# Altium schematic sheet Top sheet.SchDoc("Top sheet")
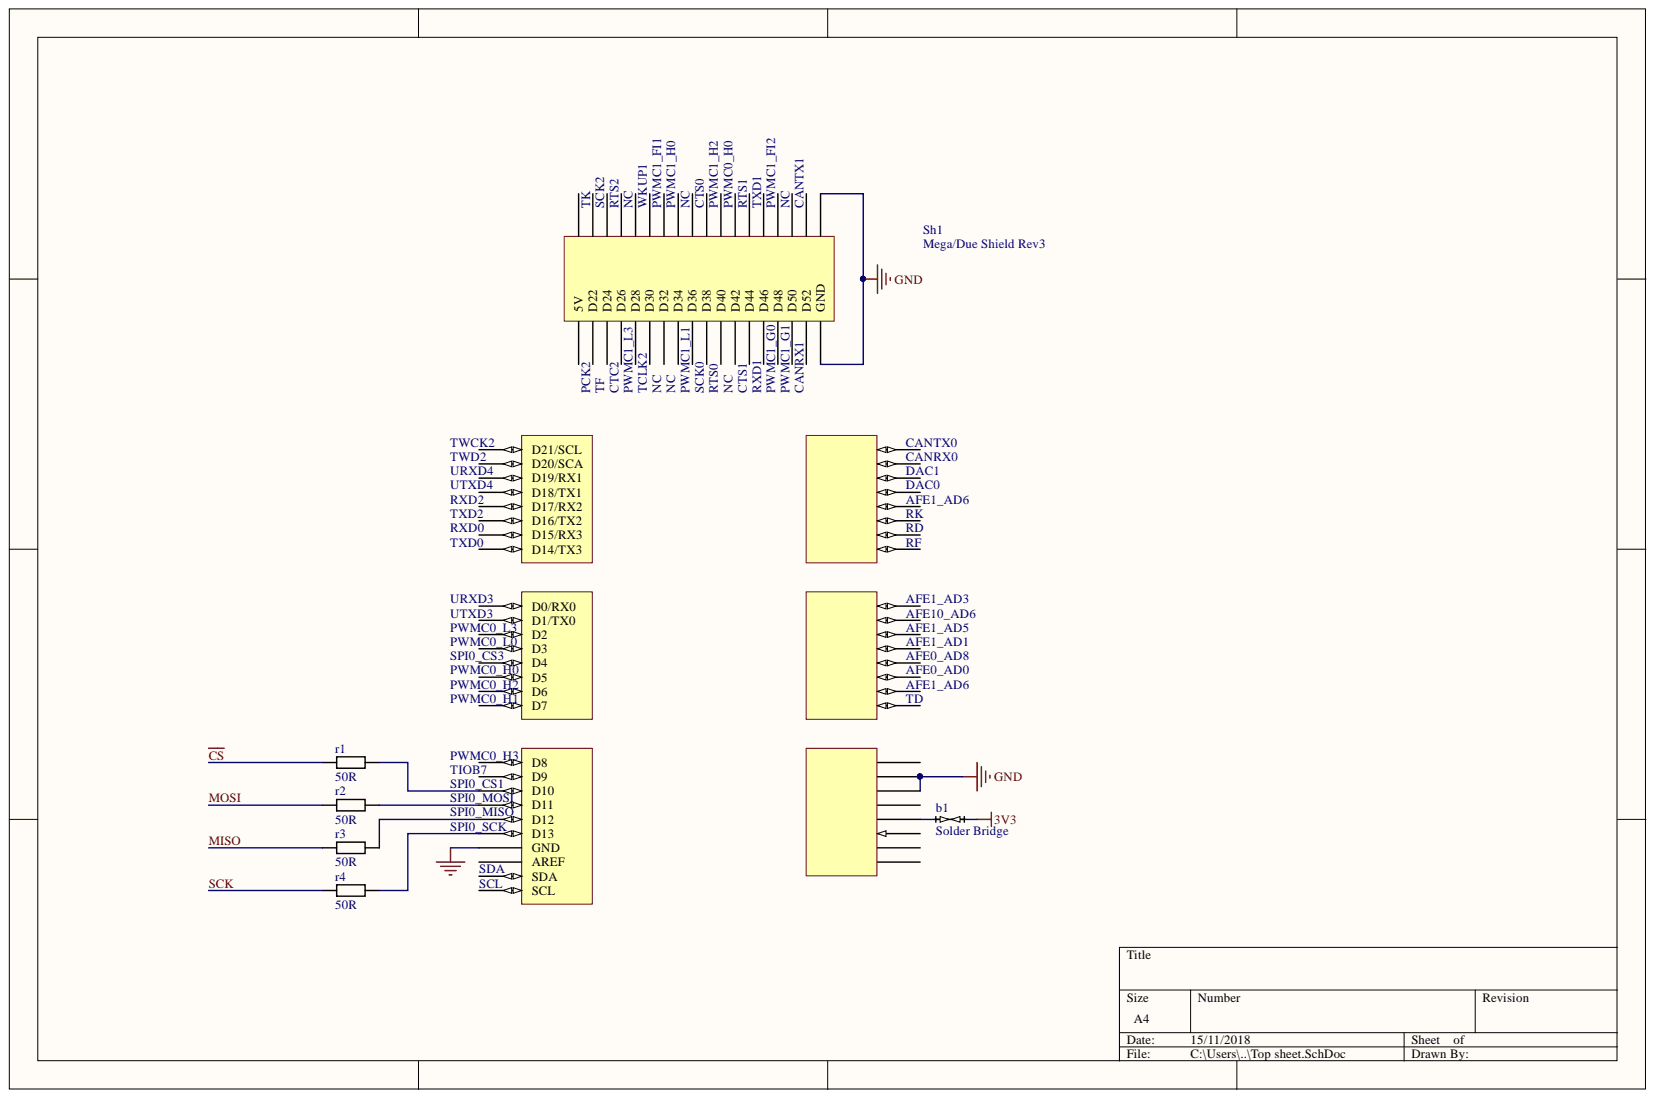
[diagram p0: Top sheet.SchDoc]

## Parts
b1  Solder Bridge  [Solder Bridge]
r1  50R  [0805]
r2  50R  [0805]
r3  50R  [0805]
r4  50R  [0805]
Sh1  Mega/Due Shield Rev3  [Mega2560_R3 Shield]

## Nets
3V3: b1.2
GND: Sh1.6 Sh1.7 Sh1.47(GND) Sh1.83 Sh1.84
~{CS}: r1.1
MISO: r3.1
MOSI: r2.1
SCK: r4.1
Netb1_1: b1.1 Sh1.4
Netr1_2: r1.2 Sh1.43(D10)
Netr2_2: r2.2 Sh1.44(D11)
Netr3_2: r3.2 Sh1.45(D12)
Netr4_2: r4.2 Sh1.46(D13)

Not connected: Sh1 1 2 3 5 8 9 10 11 12 13 14 15 16 17 18 19 20 21 22 23 24 25(D21/SCL) 26(D20/SCA) 27(D19/RX1) 28(D18/TX1) 29(D17/RX2) 30(D16/TX2) 31(D15/RX3) 32(D14/TX3) 33(D0/RX0) 34(D1/TX0) 35(D2) 36(D3) 37(D4) 38(D5) 39(D6) 40(D7) 41(D8) 42(D9) 48(AREF) 49 50 51 52 53(TF) 54 55 56 57 58 59 60 61(NC) 62 63 64 65 66 67 68 69 70 71(NC) 72 73(CTS1) 74 75 76 77 78 79 80 81 82

## Library data of the parts
r1-r4 = 0805 Resistor: ...R; Library Name: Generic R-C.SchLib; Component Kind: Standard
b1 = Solder Bridge: Description: Solder Bridge; Component Kind: Standard; Library Name: Board_Props.SchLib
Sh1 = Mega/Due Shield Rev3: Library Name: Arduino.SchLib; Component Kind: Standard
